# Altium schematic sheet FPGA-MGT.SchDoc(FPGA-MGT)
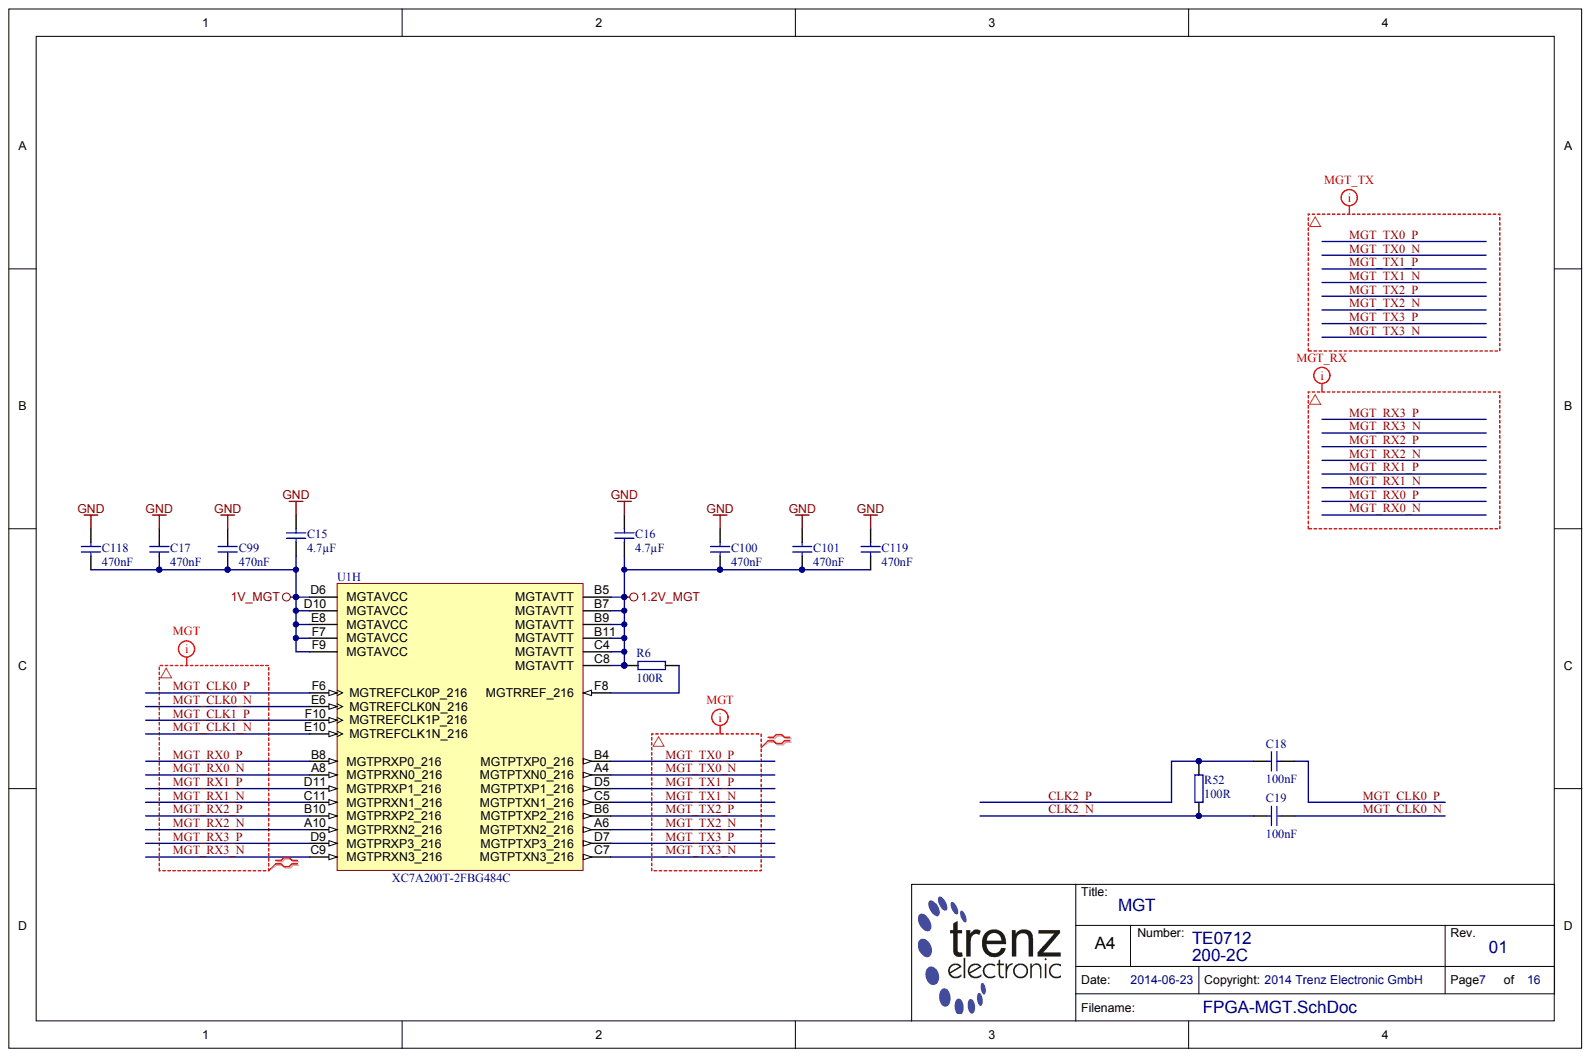
[diagram p0: FPGA-MGT.SchDoc]

## Parts
C15  4.7µF
C16  4.7µF
C17  470nF
C18  100nF
C19  100nF
C99  470nF
C100  470nF
C101  470nF
C118  470nF
C119  470nF
R6  100R
R52  100R
U1  XC7A200T-2FBG484C  (part H)

## Nets
1.2V_MGT: C16.2 C100.2 C101.2 C119.2 R6.1 U1.B5(MGTAVTT) U1.B7(MGTAVTT) U1.B9(MGTAVTT) U1.B11(MGTAVTT) U1.C4(MGTAVTT) U1.C8(MGTAVTT)
1V_MGT: C15.2 C17.2 C99.2 C118.2 U1.D6(MGTAVCC) U1.D10(MGTAVCC) U1.E8(MGTAVCC) U1.F7(MGTAVCC) U1.F9(MGTAVCC)
GND: C15.1 C16.1 C17.1 C99.1 C100.1 C101.1 C118.1 C119.1
CLK2_N: C19.1 R52.1
CLK2_P: C18.1 R52.2
MGT_CLK0_N: C19.2 U1.E6(MGTREFCLK0N_216)
MGT_CLK0_P: C18.2 U1.F6(MGTREFCLK0P_216)
MGT_CLK1_N: U1.E10(MGTREFCLK1N_216)
MGT_CLK1_P: U1.F10(MGTREFCLK1P_216)
MGT_RX0_N: U1.A8(MGTPRXN0_216)
MGT_RX0_P: U1.B8(MGTPRXP0_216)
MGT_RX1_N: U1.C11(MGTPRXN1_216)
MGT_RX1_P: U1.D11(MGTPRXP1_216)
MGT_RX2_N: U1.A10(MGTPRXN2_216)
MGT_RX2_P: U1.B10(MGTPRXP2_216)
MGT_RX3_N: U1.C9(MGTPRXN3_216)
MGT_RX3_P: U1.D9(MGTPRXP3_216)
MGT_TX0_N: U1.A4(MGTPTXN0_216)
MGT_TX0_P: U1.B4(MGTPTXP0_216)
MGT_TX1_N: U1.C5(MGTPTXN1_216)
MGT_TX1_P: U1.D5(MGTPTXP1_216)
MGT_TX2_N: U1.A6(MGTPTXN2_216)
MGT_TX2_P: U1.B6(MGTPTXP2_216)
MGT_TX3_N: U1.C7(MGTPTXN3_216)
MGT_TX3_P: U1.D7(MGTPTXP3_216)
NetR6_2: R6.2 U1.F8(MGTRREF_216)
N00780:
N00781:
N00782:
N00783:
N00784:
N00785:

## Library data of the parts
C15 C16 = (no symbol name): AN: 24719; Value: 4.7u; Voltage: 6.3; Mixed: SMT; Preferred: Yes; Case: 1005 (0402); Technology: X5R
C17 C99-C101 C118 C119 = (no symbol name): AN: 24921; Value: 470n; Voltage: 6.3V; Tolerance: 20%; Mixed: SMT; Preferred: Yes; Case: 0603 (0201); Technology: X5R
C18 C19 = (no symbol name): AN: 24915; Value: 100n; Voltage: 6.3V; Mixed: SMT; Preferred: Yes; Case: 0603 (0201); Technology: X5R
R6 R52 = (no symbol name): AN: 22507; Value: 100; Mixed: SMT; Preferred: Yes; Case: 1005 (0402)
U1 = (no symbol name): AN: 25321; Trenz-Stock: Yes; Mixed: SMT; Case: BGA484; HelpURL: B:\AD\adlib\datasheet\XC7
